# Altium schematic sheet 02_MCU.SchDoc
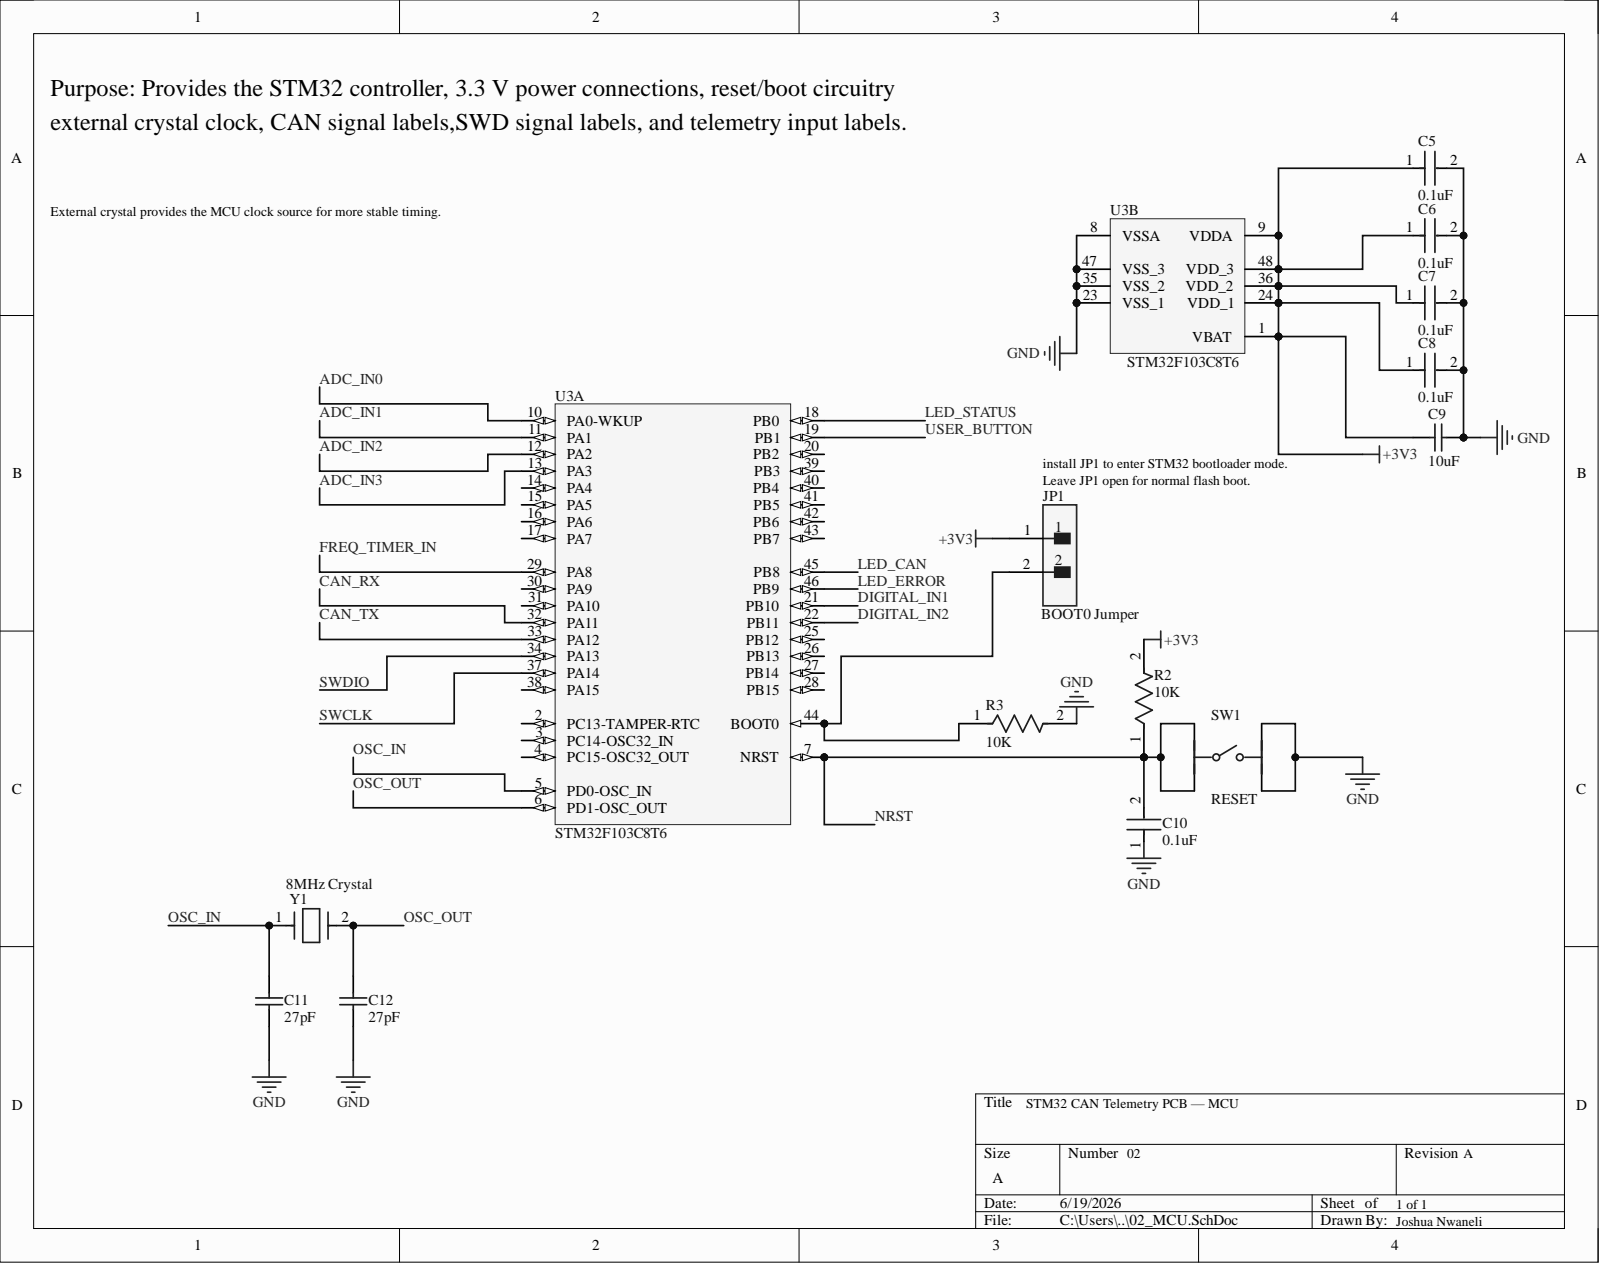
[diagram p0: 02_MCU.SchDoc]

## Parts
C5  0.1uF  [FP-CC0805-EB-MFG]
C6  0.1uF  [FP-CC0805-EB-MFG]
C7  0.1uF  [FP-CC0805-EB-MFG]
C8  0.1uF  [FP-CC0805-EB-MFG]
C9  10uF  [CAPC2013X140X50ML20T25]
C10  0.1uF  [FP-CC0805-EB-MFG]
C11  27pF  [CAPC0603X33X15ML03T05]
C12  27pF  [CAPC0603X33X15ML03T05]
JP1  BOOT0 Jumper  [FP-TSW-102-12-T-S-MFG]
R2  10K  [FP-CRGP0805-IPC_C]
R3  10K  [FP-CRGP0805-IPC_C]
SW1  RESET  [FP-7914J-1-032-MFG]
U3  STM32F103C8T6  [STM-LQFP48_L]  (parts A,B)
Y1  8MHz Crystal  Frequency: 8MHz  [FP-ABLS-MFG]

## Nets
+3V3: C5.1 C6.1 C7.1 C8.1 C9.2 JP1.1 R2.2 U3.1(VBAT) U3.9(VDDA) U3.24(VDD_1) U3.36(VDD_2) U3.48(VDD_3)
GND: C5.2 C6.2 C7.2 C8.2 C9.1 C10.1 C11.1 C12.1 R3.2 SW1.1_2+ SW1.2_2+ U3.8(VSSA) U3.23(VSS_1) U3.35(VSS_2) U3.47(VSS_3)
ADC_IN0: U3.10(PA0-WKUP)
ADC_IN1: U3.11(PA1)
ADC_IN2: U3.12(PA2)
ADC_IN3: U3.13(PA3)
CAN_RX: U3.32(PA11)
CAN_TX: U3.33(PA12)
DIGITAL_IN1: U3.21(PB10)
DIGITAL_IN2: U3.22(PB11)
FREQ_TIMER_IN: U3.29(PA8)
LED_CAN: U3.45(PB8)
LED_ERROR: U3.46(PB9)
LED_STATUS: U3.18(PB0)
NRST: C10.2 R2.1 SW1.1_1- SW1.2_1- U3.7(NRST)
OSC_IN: C11.2 U3.5(PD0-OSC_IN) Y1.1
OSC_OUT: C12.2 U3.6(PD1-OSC_OUT) Y1.2
SWCLK: U3.37(PA14)
SWDIO: U3.34(PA13)
USER_BUTTON: U3.19(PB1)
NetJP1_2: JP1.2 R3.1 U3.44(BOOT0)

Not connected: U3 2(PC13-TAMPER-RTC) 3(PC14-OSC32_IN) 4(PC15-OSC32_OUT) 14(PA4) 15(PA5) 16(PA6) 17(PA7) 20(PB2) 25(PB12) 26(PB13) 27(PB14) 28(PB15) 30(PA9) 31(PA10) 38(PA15) 39(PB3) 40(PB4) 41(PB5) 42(PB6) 43(PB7)

## Library data of the parts
C5-C8 C10 = CMP-00621-107850848-1: CC0805KKX7RYBB104 Capacitor Ceramic 0.01uF 250V X7R 10% 0805 T/R; Capacitance: 100nF; Case/Package: 0805; Component Kind: Standard; Height: 1.45mm; Library Name: Altium Content Vault; Material: Ceramic; Max Operating Temperature: 125°C; Min Operating Temperature: -55°C; NexarPartId: 107850848; Tolerance: 10%; Voltage Rating: 250V
C9 = CMP-2007-04702-1: Manufacturer: AVX Interconnect / Elco; Part Number: 0805ZC106KAT2A; Component Kind: Standard; Library Name: Altium Content Vault
C11 C12 = CMP-2010-00173-1: Manufacturer: AVX Interconnect / Elco; Part Number: 0201YA270JAT2A; Component Kind: Standard; Library Name: Altium Content Vault
JP1 = CMP-02766-001444-1: CONN HEADER VERT 2POS 2.54MM; Gender: Male; Number of Contacts: 2; Component Kind: Standard; Contact Material: Bronze; Contact Plating: Tin; Library Name: Altium Content Vault; Mount: Through Hole; Number of Rows: 1; Packaging: Bulk; Pitch: 2.54mm; Radiation Hardening: No; REACH SVHC: No SVHC; RoHS Compliant: Yes; Termination: Solder
R2 R3 = CMP-03211-006861-1: CRGP 0805 10K 1%; Manufacturer: TE; Manufacturer Part Number: CRGP0805F10K; Power Rating: 0.33W; Resistance: 10KΩ; Temperature Coefficient: ±100ppm/°C; Tolerance: 0.01; Value: 10KΩ; Voltage Rating: 150V; Ambient Temperature Range High: +70°C; Automotive: No; Category: Res; Component Kind: Standard; ComponentLink2Description: Datasheet; ComponentLink2URL: https://www.mouser.com/datasheet/2/418/NG_DS_9-1773463-9_A-1358566.pdf; Composition: Thick Film; Device Class L1: Passive Components; Device Class L2: Resistors; Device Class L3: Chip SMD Resistors; Digikey Description: CRGP 0805 10K 1%; Height: 0.65mm; Ipc Land Pattern Name: RESC200125X55; Lead Free: Yes; Library Name: Altium Content Vault; Octopart: https://octopart.com/crgp0805f10k-te+connectivity-91018782; Package: CRGP0805; RoHS: TRUE; Series: CRGP; Temperature: -55°C to +155°C; Temperature Range High: +155°C; Temperature Range Low: -55°C
SW1 = CMP-07427-000007-1: Normally open reset pushbutton for STM32 NRST; Actuator Material: Rubber; Actuator Type: Standard; Current Rating: 100mA; Illumination Type: Non-Illuminated; Mechanical Life: 100000Cycles; Operating Force: 300gf; Switch Function: Off-Mom; Throw Configuration: SPST; Voltage Rating (DC): 16V; Case/Package: SMD/SMT; Component Kind: Standard; Contact Material: Gold; Contact Plating: Silver; Depth: 5mm; Length: 5mm; Library Name: Altium Content Vault; Max Current Rating: 100mA; Max Operating Temperature: 125°C; Min Operating Temperature: -55°C; Mount: Surface Mount; Orientation: Straight; Package Quantity: 50; Packaging: Rail/Tube; RoHS Compliant: Yes; Series: 7914; Termination: J-Hook
U3 = CMP-0237-00215-3: ARM Cortex-M3 32-bit MCU, 64 KB Flash, 20 KB Internal RAM, 37 I/Os, 48-pin LQFP, -40 to 85 degC, Tray; A/D Converter: 2x12-bit (10 Channel); FLASH Size (Prog) (kB): 64; I/Os (High Current): 37; Internal RAM Size (kB): 20; Operating Frequency(F) (Processor speed) (MHz): 72; Supply Voltage(Vcc) min (V): 2; Component Kind: Standard; ComponentLink1Description: Manufacturer URL; ComponentLink1URL: http://www.st.com/web/en/home.html; ComponentLink2Description: Datasheet; ComponentLink2URL: http://www.st.com/st-web-ui/static/active/en/resource/technical/document/datasheet/CD00161566.pdf; ComponentLink3Description: Package Specification; ComponentLink3URL: http://www.st.com/st-web-ui/static/active/en/resource/technical/document/datasheet/DM00058181.pdf; Core: ARM Cortex-M3; DatasheetVersion: Rev. 16; Library Name: Altium Content Vault; Mounting Technology: Surface Mount; PackageDescription: 48-Pin Low Profile Quad Flat Package, 7 x 7 mm Body, 0.5 mm Pitch; PackageReference: LQFP48; PackageVersion: Rev. 6, 06/2013; Packing: Tray; PartNumber: STM32F103C8T6
Y1 = CMP-27762-009874-1: CRYSTAL 8.0000MHZ 18PF SMD; Accuracy: 20ppm; ESR (Equivalent Series Resistance): 80R; Frequency Stability: 50ppm; Case/Package: SMD/SMT; Component Kind: Standard; Contact Plating: Tin; Depth: 4.7mm; Frequency Tolerance: 20ppm; Height: 0.165inch; Lead Free: Lead Free; Length: 11.4mm; Library Name: Altium Content Vault; Load Capacitance: 18pF; Max Operating Temperature: 70°C; Min Operating Temperature: -20°C; Mount: Surface Mount; Number of Pins: 2; Operating Frequency: 8 MHz; Package Quantity: 1000; Packaging: Tape and Reel; Radiation Hardening: No; REACH SVHC: No SVHC; Series: ABLS; Series Resistance: 80R; Termination: SMD/SMT; Tolerance: 20ppm; Width: 4.7mm
